# Altium schematic sheet Sheet1.SchDoc
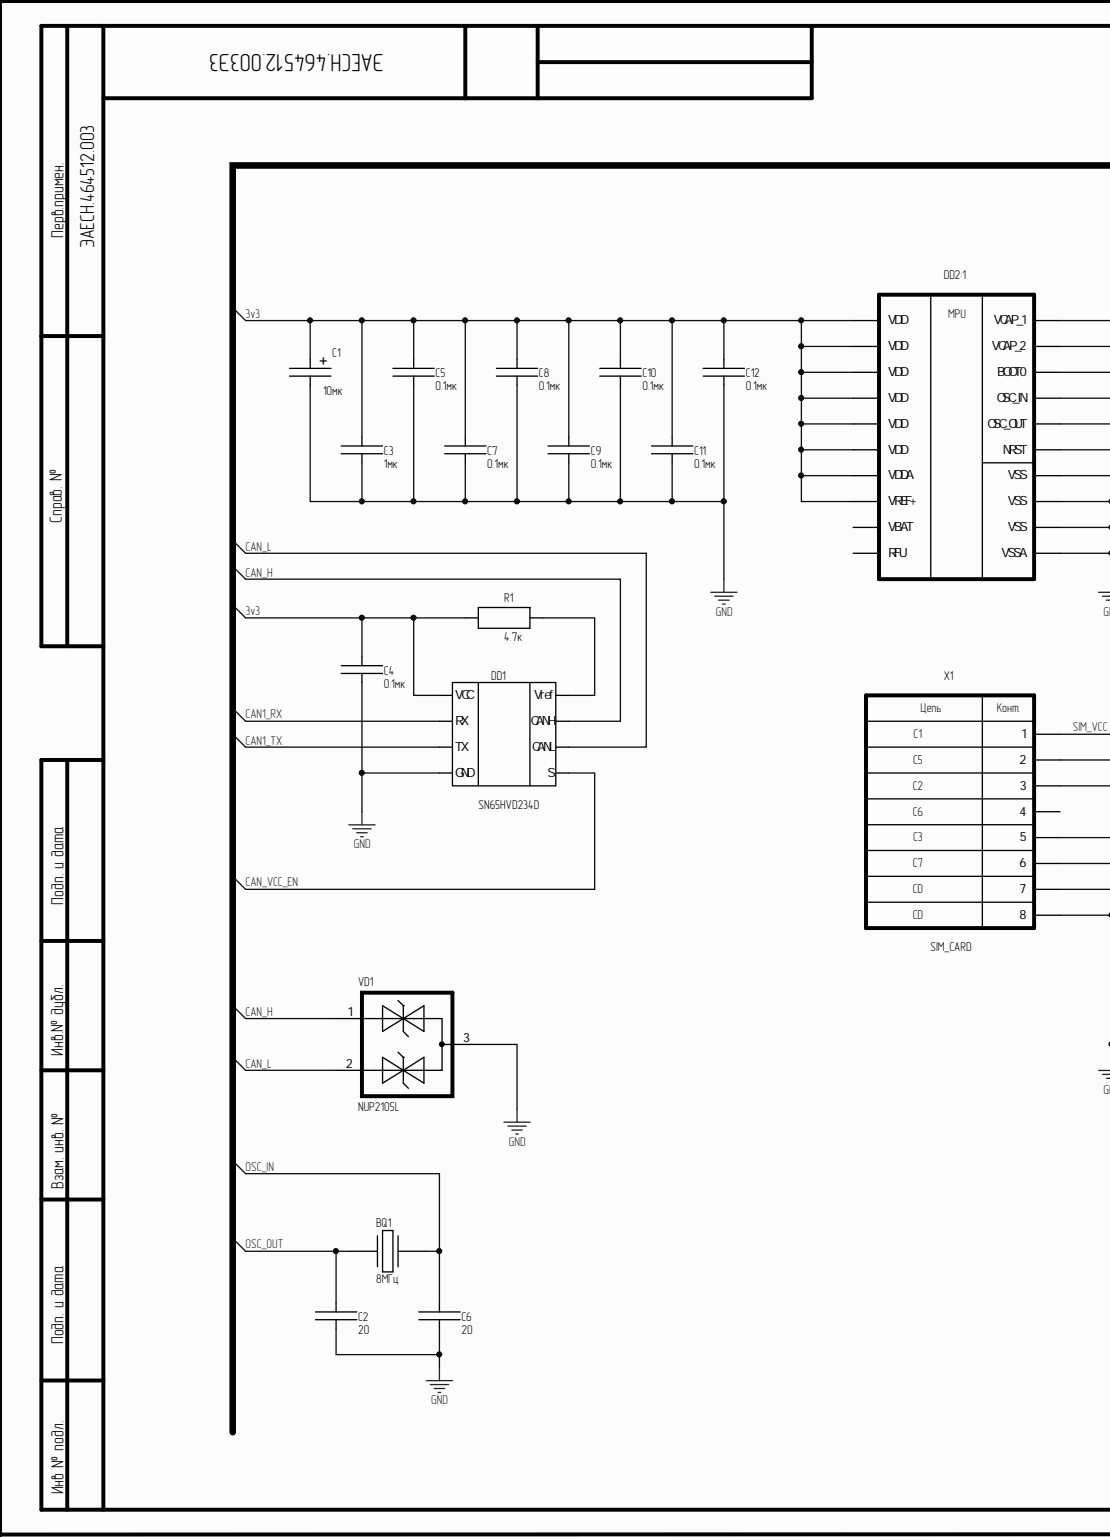
[diagram p0: Sheet1.SchDoc, left]
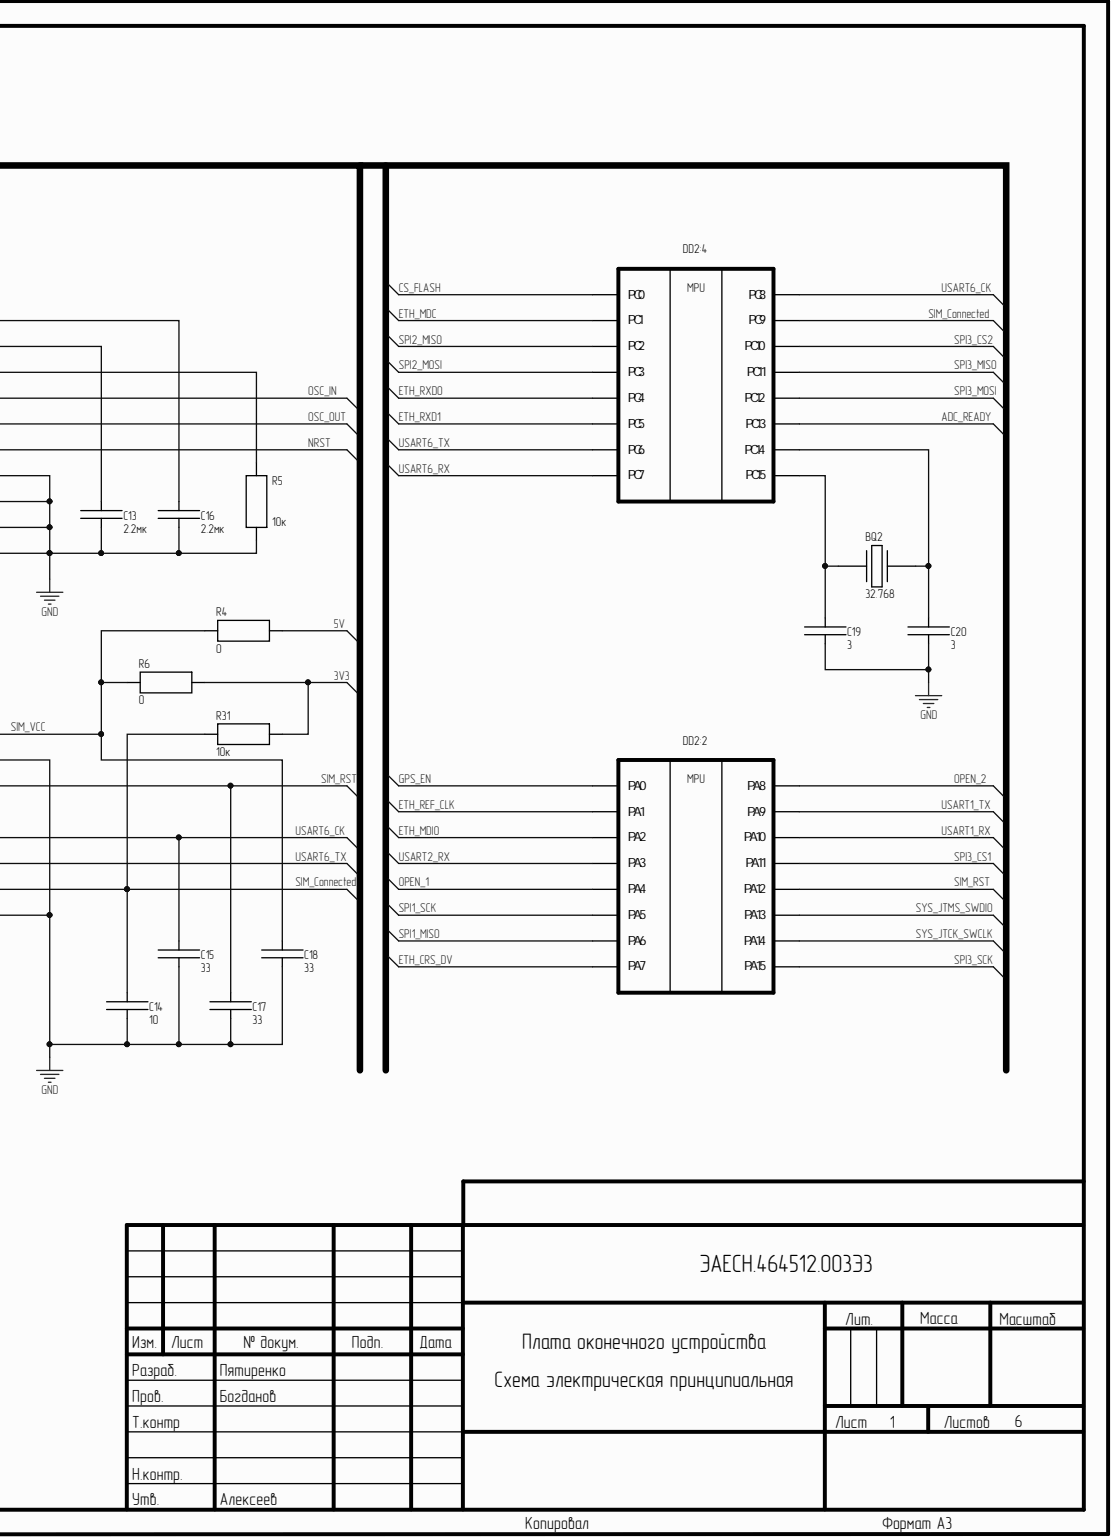
[diagram p1: Sheet1.SchDoc, right]

## Parts
BQ1  value 8МГц  [Crystal_0532]  (hidden comment: Quarz)
BQ2  value 32.768  [ABS07]  (hidden comment: ABS07)
C1  value 10мк  [Case A]  (hidden comment: C_el)
C2  C  value 20  [С0603]
C3  C  value 1мк  [С0603]
C4  C  value 0.1мк  [С0603]
C5  C  value 0.1мк  [С0603]
C6  C  value 20  [С0603]
C7  C  value 0.1мк  [С0603]
C8  C  value 0.1мк  [С0603]
C9  C  value 0.1мк  [С0603]
C10  C  value 0.1мк  [С0603]
C11  C  value 0.1мк  [С0603]
C12  C  value 0.1мк  [С0603]
C13  C  value 2.2мк  [С0603]
C14  C  value 10  [С0603]
C15  C  value 33  [С0603]
C16  C  value 2.2мк  [С0603]
C17  C  value 33  [С0603]
C18  C  value 33  [С0603]
C19  C  value 3  [С0603]
C20  C  value 3  [С0603]
DD1  SN65HVD234D  [SOIC8]
DD2  STM32F207VCTx  [LQFP100]  (value not drawn)  (parts :1,:2,:4)
R1  R  value 4.7к  [R0603]
R4  R  value 0  [R0603]
R5  R  value 10к  [R0603]
R6  R  value 0  [R0603]
R31  R  value 10к  [R0603]
VD1  NUP2105L  [SOT-23]
X1  SIM_CARD  [SIM_CARD_READER2]

## Nets
GND: C1.2 C2.2 C3.2 C4.2 C5.2 C6.2 C7.2 C8.2 C9.2 C10.2 C11.2 C12.2 C13.2 C14.2 C15.2 C16.2 C17.2 C18.2 C19.2 C20.2 DD1.2(GND) DD2.10(VSS) DD2.20(VSSA) DD2.27(VSS) DD2.74(VSS) R5.2 VD1.3 X1.2 X1.8
3V3: C1.1 C3.1 C4.1 C5.1 C7.1 C8.1 C9.1 C10.1 C11.1 C12.1 DD1.3(VCC) DD2.11(VDD) DD2.19(VDD) DD2.21(VREF+) DD2.22(VDDA) DD2.28(VDD) DD2.50(VDD) DD2.75(VDD) DD2.100(VDD) R1.1 R6.2 R31.2
5V: R4.2
ADC_READY: DD2.7(PC13)
CAN1_RX: DD1.4(RX)
CAN1_TX: DD1.1(TX)
CAN_H: DD1.7(CANH) VD1.1
CAN_L: DD1.6(CANL) VD1.2
CAN_VCC_EN: DD1.8(S)
CS_FLASH: DD2.15(PC0)
ETH_CRS_DV: DD2.32(PA7)
ETH_MDC: DD2.16(PC1)
ETH_MDIO: DD2.25(PA2)
ETH_REF_CLK: DD2.24(PA1)
ETH_RXD0: DD2.33(PC4)
ETH_RXD1: DD2.34(PC5)
GPS_EN: DD2.23(PA0)
NRST: DD2.14(NRST)
OPEN_1: DD2.29(PA4)
OPEN_2: DD2.67(PA8)
OSC_IN: BQ1.2 C6.1 DD2.12(OSC_IN)
OSC_OUT: BQ1.1 C2.1 DD2.13(OSC_OUT)
SIM_Connected: C14.1 DD2.66(PC9) R31.1 X1.7
SIM_RST: C17.1 DD2.71(PA12) X1.3
SIM_VCC: C18.1 R4.1 R6.1 X1.1
SPI1_MISO: DD2.31(PA6)
SPI1_SCK: DD2.30(PA5)
SPI2_MISO: DD2.17(PC2)
SPI2_MOSI: DD2.18(PC3)
SPI3_CS1: DD2.70(PA11)
SPI3_CS2: DD2.78(PC10)
SPI3_MISO: DD2.79(PC11)
SPI3_MOSI: DD2.80(PC12)
SPI3_SCK: DD2.77(PA15)
SYS_JTCK_SWCLK: DD2.76(PA14)
SYS_JTMS_SWDIO: DD2.72(PA13)
USART1_RX: DD2.69(PA10)
USART1_TX: DD2.68(PA9)
USART2_RX: DD2.26(PA3)
USART6_CK: C15.1 DD2.65(PC8) X1.5
USART6_RX: DD2.64(PC7)
USART6_TX: DD2.63(PC6) X1.6
NetBQ2_1: BQ2.1 C19.1 DD2.9(PC15)
NetBQ2_2: BQ2.2 C20.1 DD2.8(PC14)
NetC13_1: C13.1 DD2.73(VCAP_2)
NetC16_1: C16.1 DD2.49(VCAP_1)
NetDD1_5: DD1.5(Vref) R1.2
NetDD2_94: DD2.94(BOOT0) R5.1

Not connected: DD2 6(VBAT) 99(RFU); X1 4

## Library data of the parts
BQ1 = Quarz: Component Kind: Standard; Library Name: Schlib1.SchLib
BQ2 = ABS07: Component Kind: Standard; Library Name: Schlib1.SchLib
C1 = C_el: Component Kind: Standard; Library Name: Schlib1.SchLib
C2-C20 = C: Component Kind: Standard; Library Name: Schlib1.SchLib
DD1 = SN65HVD234D: Component Kind: Standard; Library Name: Schlib1.SchLib
DD2 = STM32F207VCTx: Component Kind: Standard; Library Name: Schlib1.SchLib
R1 R4-R6 R31 = R: Component Kind: Standard; Library Name: Schlib1.SchLib
VD1 = NUP2105L: Component Kind: Standard; Library Name: Schlib1.SchLib
X1 = SIM_CARD: Component Kind: Standard; Library Name: Schlib1.SchLib
